# Altium schematic sheet Power.SchDoc
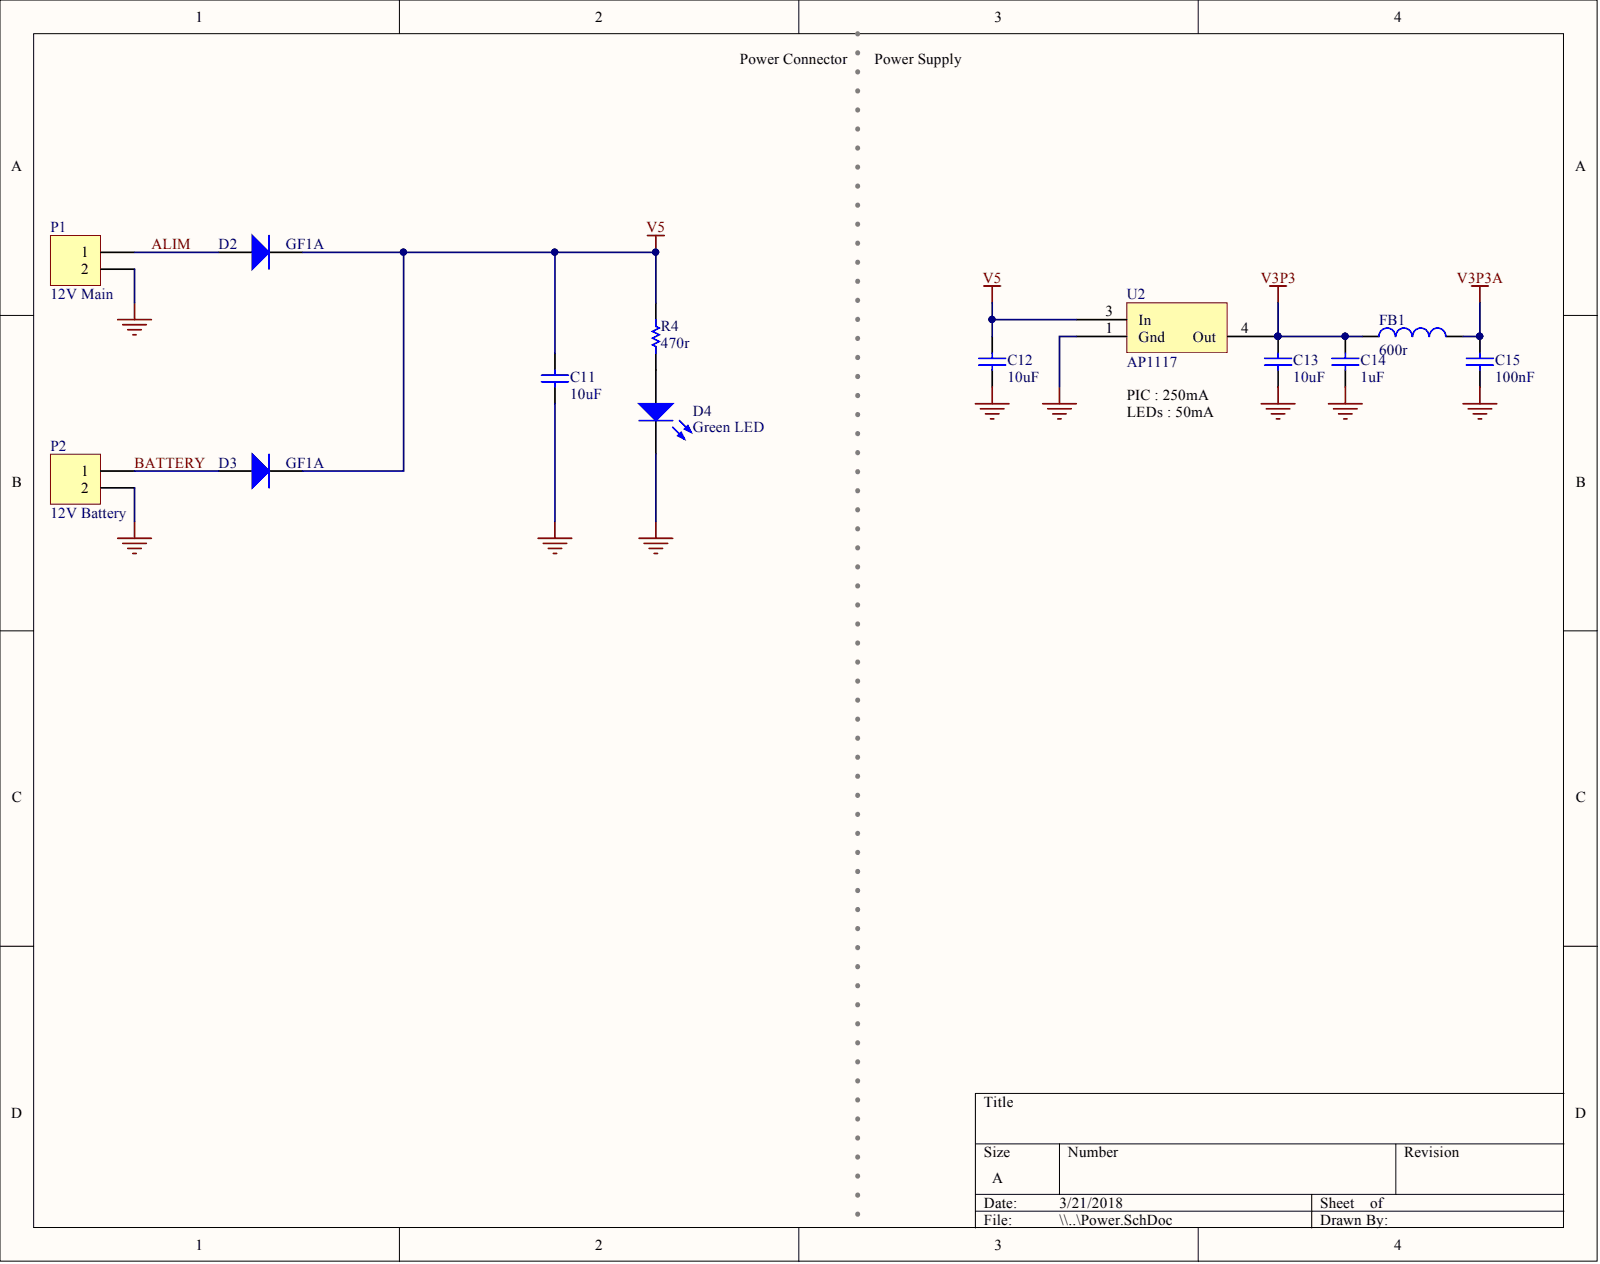
[diagram p0: Power.SchDoc]

## Parts
C11  10uF  [CAPC2012N]
C12  10uF  [CAPC2012N]
C13  10uF  [CAPC2012N]
C14  1uF  [CAPC1608N]
C15  100nF  [CAPC1608N]
D2  GF1A  [214AC_21]
D3  GF1A  [214AC_21]
D4  Green LED  [LED 0805]
FB1  600r  [INDC1608AN]
P1  12V Main  [PHOENIX_1985195]
P2  12V Battery  [PHOENIX_1985195]
R4  470r  [RESC1608N]
U2  AP1117  [TD03B_N]

## Nets
GND: C11.1 C12.1 C13.1 C14.1 C15.1 D4.2 P1.2 P2.2 U2.1
V3P3: C13.2 C14.2 FB1.1 U2.4
V3P3A: C15.2 FB1.2
V5: C11.2 C12.2 D2.2 D3.2 R4.2 U2.3
ALIM: D2.1 P1.1
BATTERY: D3.1 P2.1
NetD4_1: D4.1 R4.1

## Library data of the parts
C11-C13 = Cap: Capacitor; Fabricant: TDK; Fournisseur: Farnell; Precision: 6.3V X5R 10uF; Ref.Fabricant: C2012X5R0J106M/1.25; Ref.Fournisseur: 2309029; Component Kind: Standard; Library Name: Miscellaneous Devices.IntLib; Signal Integrity: Cap; Simulation: CAP; Valeur: 10uF
C14 = Cap: Capacitor; Precision: 6.3V X5R; Component Kind: Standard; Library Name: Miscellaneous Devices.IntLib; Signal Integrity: Cap; Simulation: CAP; Valeur: 1uF
C15 = Cap: Capacitor; Precision: 50V X5R; Component Kind: Standard; Library Name: Miscellaneous Devices.IntLib; Signal Integrity: Cap; Simulation: CAP; Valeur: 100nF
D2 D3 = Diode: Default Diode; Fabricant: VISHAY; Fournisseur: Farnell; Ref.Fabricant: 6FIA-E3/67A; Ref.Fournisseur: 9549560; Component Kind: Standard; Library Name: Miscellaneous Devices.IntLib; Signal Integrity: Diode; Simulation: DIODE
D4 = LED0: Typical INFRARED GaAs LED; Fabricant: KING BRIGHT; Fournisseur: Farnell; Ref.Fabricant: KPT-2012SGC; Ref.Fournisseur: 2099239; Component Kind: Standard; Library Name: Miscellaneous Devices.IntLib; Simulation: LED0
FB1 = Inductor: Fabricant: WURTH; Fournisseur: Farnell; Ref.Fabricant: 472792651; Ref.Fournisseur: 1635706; Component Kind: Standard; Description: Inductor; Library Name: Miscellaneous Devices.IntLib; Signal Integrity: Inductor; Simulation: INDUCTOR; Valeur: 600r
P1 P2 = Header 2: Header, 2-Pin; Fabricant: PHOENIX CONTACT; Fournisseur: Farnell; Ref.Fabricant: PTSA 1.5/2-3,5-Z; Ref.Fournisseur: 1792769; Component Kind: Standard; Library Name: Miscellaneous Connectors.IntLib; Signal Integrity: Connector
R4 = Res1: Resistor; Precision: 1%; Valeur: 4.7k; Component Kind: Standard; Library Name: Miscellaneous Devices.IntLib; Signal Integrity: Res; Simulation: RESISTOR
U2 = AP1117: Fabricant: DIODES INC; Fournisseur: Farnell; Ref.Fabricant: AP1117D33G-13; Ref.Fournisseur: 1825285; Component Kind: Standard; Library Name: Schlib1.SchLib
